# Altium schematic sheet ESP32.SchDoc
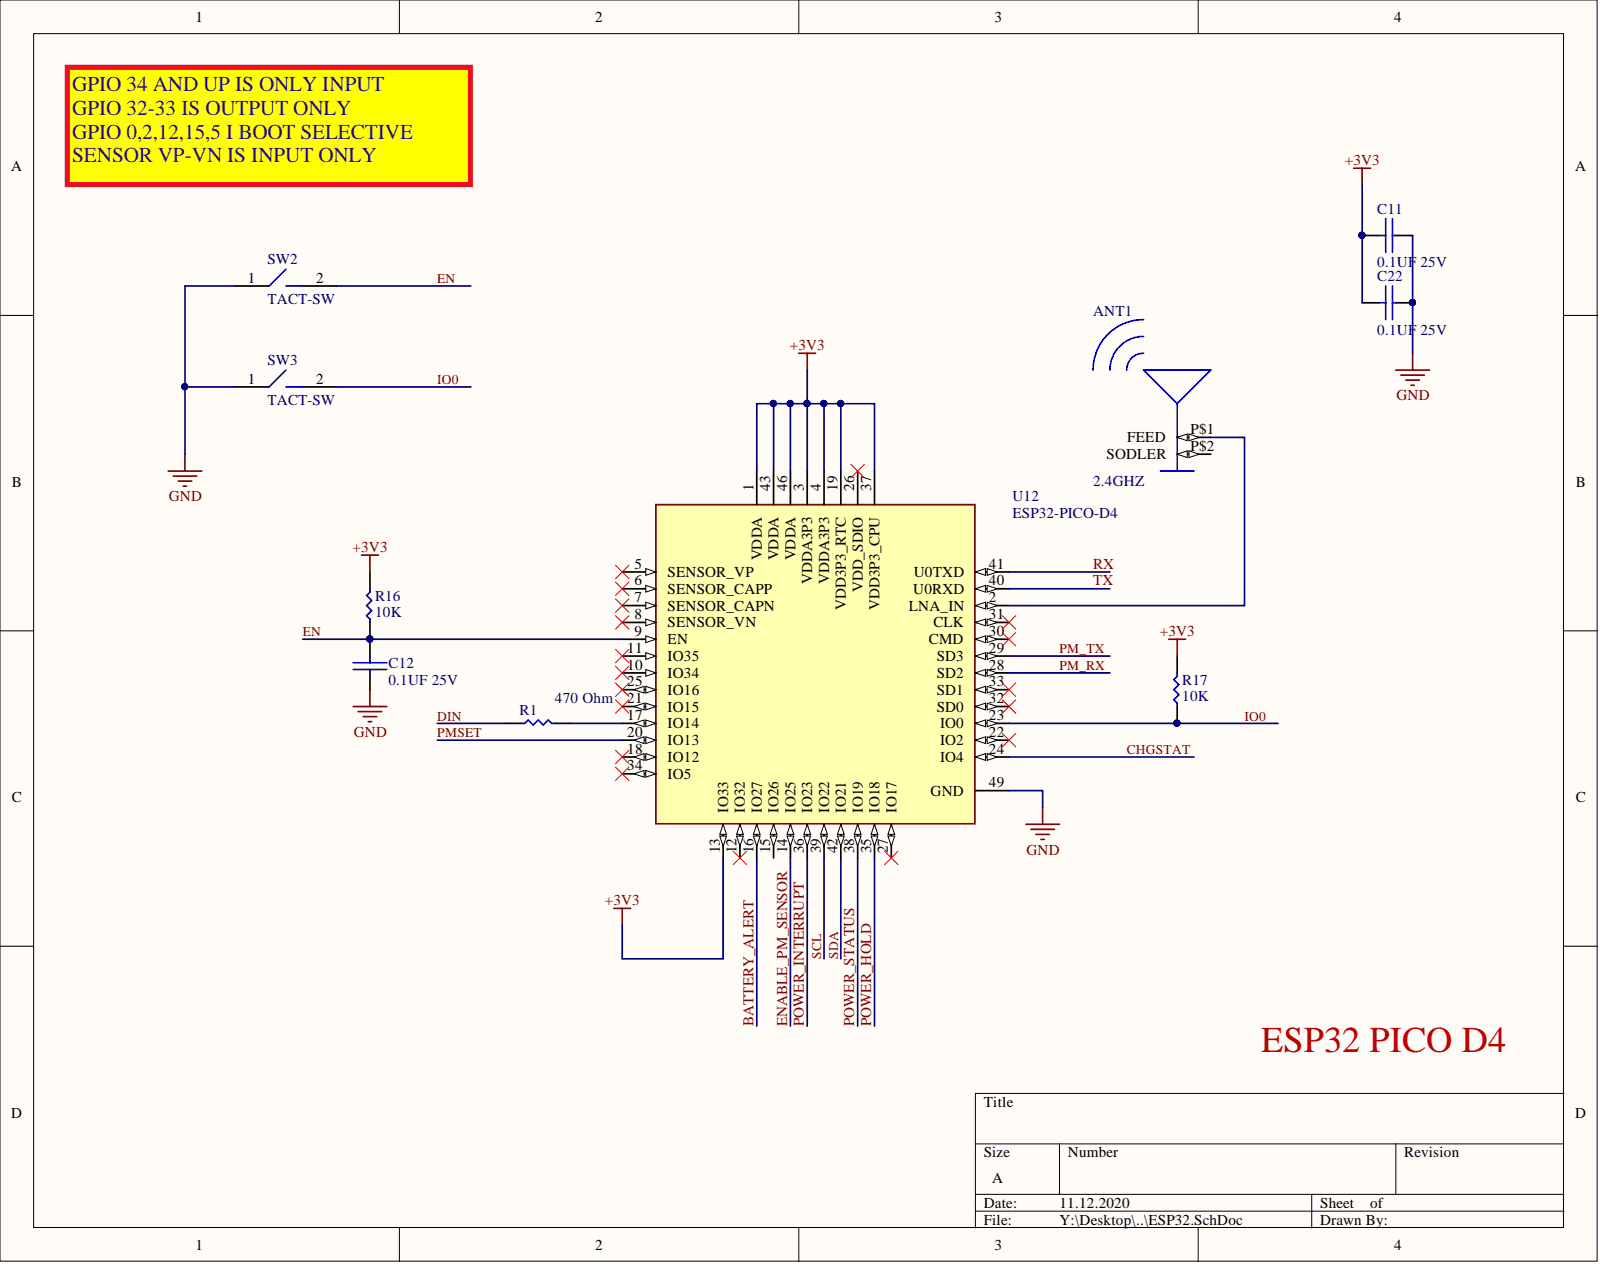
[diagram p0: ESP32.SchDoc]

## Parts
ANT1  2.4GHZ  [AN2051]
C11  0.1UF 25V  [C0603]
C12  0.1UF 25V  [C0603]
C22  0.1UF 25V  [C0603]
R1  470 Ohm  [R0603]
R16  10K  [R0603]
R17  10K  [R0603]
SW2  TACT-SW  [kmr7-switch]
SW3  TACT-SW  [kmr7-switch]
U12  ESP32-PICO-D4  [PQFN50P700X700X104-49N]

## Nets
+3V3: C11.2 C22.2 R16.2 R17.2 U12.1(VDDA) U12.3(VDDA3P3) U12.4(VDDA3P3) U12.13(IO33) U12.19(VDD3P3_RTC) U12.37(VDD3P3_CPU) U12.43(VDDA) U12.46(VDDA)
GND: C11.1 C12.1 C22.1 SW2.1 SW3.1 U12.49(GND)
BATTERY_ALERT: U12.16(IO27)
CHGSTAT: U12.24(IO4)
DIN: R1.1
EN: C12.2 R16.1 SW2.2 U12.9(EN)
ENABLE_PM_SENSOR: U12.14(IO25)
IO0: R17.1 SW3.2 U12.23(IO0)
PM_RX: U12.28(SD2)
PM_TX: U12.29(SD3)
PMSET: U12.20(IO13)
POWER_HOLD: U12.35(IO18)
POWER_INTERRUPT: U12.36(IO23)
POWER_STATUS: U12.38(IO19)
RX: U12.41(U0TXD)
SCL: U12.39(IO22)
SDA: U12.42(IO21)
TX: U12.40(U0RXD)
NetANT1_P$1: ANT1.P$1 U12.2(LNA_IN)
NetR1_2: R1.2 U12.17(IO14)

Not connected: ANT1 P$2; U12 5(SENSOR_VP) 6(SENSOR_CAPP) 7(SENSOR_CAPN) 8(SENSOR_VN) 10(IO34) 11(IO35) 12(IO32) 15(IO26) 18(IO12) 21(IO15) 22(IO2) 25(IO16) 26(VDD_SDIO) 27(IO17) 30(CMD) 31(CLK) 32(SD0) 33(SD1) 34(IO5)

## Library data of the parts
ANT1 = AN2051: SMD Built-in Antenna 2.4GHz; MF: RainSun; Availability: Unavailable; Component Kind: Standard; MP: AN2051; Package: None; Price: None; SnapEDA_Link: https://www.snapeda.com/parts/AN2051/RainSun/view-part/550460/?ref=snap; Library Name: salcox.IntLib
C11 C12 C22 = 06033D104KAT2A: CAP CER 0.1UF 25V X5R 0603; Manufacturer: Kyocera AVX; Capacitance: 100 nF; Tolerance (%): ±10 %; Case Size/Dimension: 0603; Component Kind: Standard; ComponentLink1Description: https://datasheet.ciiva.com/19475/1031061-19475906.pdf; ComponentLink1URL: https://datasheet.ciiva.com/19475/1031061-19475906.pdf; ComponentLink2Description: https://datasheet.ciiva.com/28613/759405-28613274.pdf; ComponentLink2URL: https://datasheet.ciiva.com/28613/759405-28613274.pdf; ComponentLink3Description: https://datasheet.ciiva.com/16397/cx5r-16397162.pdf; ComponentLink3URL: https://datasheet.ciiva.com/16397/cx5r-16397162.pdf; ComponentLink4Description: https://datasheet.ciiva.com/28613/1666675-28613037.pdf; ComponentLink4URL: https://datasheet.ciiva.com/28613/1666675-28613037.pdf; ComponentLink5Description: https://datasheet.ciiva.com/28613/1666675-28613275.pdf; ComponentLink5URL: https://datasheet.ciiva.com/28613/1666675-28613275.pdf; ComponentLink6Description: https://datasheet.ciiva.com/152/cx5r-152227.pdf; ComponentLink6URL: https://datasheet.ciiva.com/152/cx5r-152227.pdf; ComponentLink7Description: https://datasheet.ciiva.com/152/cx5r-152226.pdf; ComponentLink7URL: https://datasheet.ciiva.com/152/cx5r-152226.pdf; ComponentLink8Description: https://datasheet.ciiva.com/2987/cx5r-2987761.pdf; ComponentLink8URL: https://datasheet.ciiva.com/2987/cx5r-2987761.pdf; ComponentLink9Description: https://datasheet.ciiva.com/152/cx5r-152225.pdf; ComponentLink9URL: https://datasheet.ciiva.com/152/cx5r-152225.pdf; ComponentLink10Description: https://datasheet.ciiva.com/2987/cx5r-2987762.pdf; ComponentLink10URL: https://datasheet.ciiva.com/2987/cx5r-2987762.pdf; Dielectric: X5R; Manufacturer Part Number: 06033D104KAT2A; Pricing 1: 1=0.32, 10=0.221, 100=0.1328, 500=0.0996, 1000=0.0841 (USD); Rated Voltage: 25 V; Stock 1: 0; Supplier 1: Digi-Key; Supplier Part Number 1: 478-1244-6-ND; Library Name: salcox.IntLib
R1 = CRCW0603-470RFKEA: SMD Resistor, Thick film 470 Ohm,  ±  1 %, 0603, CRCW0603470RFKEA, Vishay; Brand: Vishay / Dale; Power Rating: 100 mW; Resistance: 470 Ohms; Temperature Coefficient: 100 PPM / K; Tolerance: 1 %; Unit Weight: 0.000071 oz; Voltage Rating: 75 V; Case Code - in: 0603; Case Code - mm: 1608; Component Kind: Standard; ComponentLink1Description: http://www.vishay.com/docs/20035/dcrcwe3.pdf?src-supplier=Digi-Key; ComponentLink1URL: http://www.vishay.com/docs/20035/dcrcwe3.pdf?src-supplier=Digi-Key; ComponentLink2Description: http://uk.rs-online.com/webdocs/13ec/0900766b813ecbec.pdf?src-supplier=RSComponents; ComponentLink2URL: http://uk.rs-online.com/webdocs/13ec/0900766b813ecbec.pdf?src-supplier=RSComponents; ComponentLink3Description: https://www.verical.com/server-webapp/html/getDatasheet?partID=45798&src-supplier=Verical; ComponentLink3URL: https://www.verical.com/server-webapp/html/getDatasheet?partID=45798&src-supplier=Verical; ComponentLink4Description: http://www.vishay.com/docs/20008/dcrcw.pdf?src-supplier=Avnet+Europe; ComponentLink4URL: http://www.vishay.com/docs/20008/dcrcw.pdf?src-supplier=Avnet+Europe; ComponentLink5Description: http://www.vishay.com/docs/20035/dcrcwe3.pdf?src-supplier=Avnet; ComponentLink5URL: http://www.vishay.com/docs/20035/dcrcwe3.pdf?src-supplier=Avnet; ComponentLink6Description: http://www.mouser.com/ds/2/427/dcrcwe3-109170.pdf?src-supplier=Mouser; ComponentLink6URL: http://www.mouser.com/ds/2/427/dcrcwe3-109170.pdf?src-supplier=Mouser; ComponentLink7Description: http://www.farnell.com/datasheets/2310790.pdf?src-supplier=Element14; ComponentLink7URL: http://www.farnell.com/datasheets/2310790.pdf?src-supplier=Element14; ComponentLink8Description: http://www.farnell.com/datasheets/2045673.pdf?src-supplier=Element14; ComponentLink8URL: http://www.farnell.com/datasheets/2045673.pdf?src-supplier=Element14; ComponentLink9Description: https://www.distrelec.biz/Web/Downloads/a_/en/flD-CRCW-e3_data_en.pdf?mime=application%2Fpdf&src-supplier=Distrelec; ComponentLink9URL: https://www.distrelec.biz/Web/Downloads/a_/en/flD-CRCW-e3_data_en.pdf?mime=application%2Fpdf&src-supplier=Distrelec; Factory Pack Quantity: 5000; Height: 0.45 mm; Length: 1.55 mm; Manufacturer: Vishay; Manufacturer Part Number: CRCW0603-470RFKEA; Operating Temperature Range: - 55 C to + 155 C; Package / Case: 0603 (1608 metric); Packaging: Reel; Product: Thick Film Resistors SMD; Product Category: Thick Film Resistors - SMD; RoHS: Details; Series: CRCW; Stock 1: 0; Supplier 1: Digi-Key; Supplier Part Number 1: 541-470HTR-ND; Termination Style: SMD/SMT; Width: 0.85 mm; Library Name: salcox.IntLib
R16 R17 = RC0603FR-0710KL: RES SMD 10K OHM 1% 1/10W 0603; Manufacturer: Yageo; Power (Watts): 0.1W, 1/10W; Temperature Coefficient: ±100ppm/°C; Category: Resistors; Component Kind: Standard; ComponentLink1Description: http://www.yageo.com/documents/recent/PYu-RC_Group_51_RoHS_L_8.pdf; ComponentLink1URL: http://www.yageo.com/documents/recent/PYu-RC_Group_51_RoHS_L_8.pdf; Composition: Thick Film; Failure Rate: -; Features: Moisture Resistant; Height - Seated (Max): 0.022" (0.55mm); Manufacturer Part Number: RC0603FR-0710KL; Operating Temperature: -55°C ~ 155°C; Package / Case: 0603 (1608 Metric); Packaging: Digi-Reel®; Part Status: Active; Pricing 1: 1=0.1, 10=0.015, 100=0.006, 1000=0.00268, 2500=0.00233 (USD); RoHS: RoHS Compliant; Size / Dimension: 0.063" L x 0.032" W (1.60mm x 0.80mm); Stock 1: 5916612; Supplier 1: Digi-Key; Supplier Device Package: 0603; Supplier Part Number 1: 311-10.0KHRDKR-ND; Tolerance: ±1%; Library Name: salcox.IntLib
SW2 SW3 = KMR731NGLFS: switch; Manufacturer: ITT C&K; Actuator Height off PCB, from Pins: 2.50mm; Actuator Orientation: Top Actuated; Actuator Type: Standard; Circuit: SPST-NO; Contact Rating @ Voltage: 0.05A @ 32VDC; Illumination: Non-Illuminated; Ingress Protection: IP67 - Dust Tight, Waterproof; Mechanical Life: 150,000 Cycles; Operating Force: 300gf; Outline: 4.20mm x 2.80mm; Switch Function: Off-Mom; Actuator Material: -; Category: Switches; Component Kind: Standard; Family: Tactile Switches; Features: -; Illumination Type, Color: -; Illumination Voltage (Nominal): -; Manufacturer Part Number: KMR731NGLFS; Mounting Type: Surface Mount; Operating Temperature: -40°C ~ 85°C; Packaging: Tape & Reel (TR); Pricing 1: 1=0.34, 10=0.333, 50=0.309, 100=0.298, 1000=0.203, 10000=0.167 (USD); Series: KMR7; Stock 1: 5277; Supplier 1: Mouser; Supplier Part Number 1: 611-KMR731NGLFS; Switch Travel: -; Termination Style: Gull Wing; Library Name: salcox.IntLib
U12 = ESP32-PICO-D4: Bluetooth, WiFi 802.11b/g/n, Bluetooth v4.2 + EDR, Class 1, 2 and 3 Transceiver Module 2.4GHz ~ 2.5GHz Surface Mount; MF: Espressif Systems; Availability: Unavailable; Component Kind: Standard; MP: ESP32-PICO-D4; Package: SMD-48 Espressif Systems; Price: None; SnapEDA_Link: https://www.snapeda.com/parts/ESP32-PICO-D4/Espressif%20Systems/view-part/2762121/?ref=snap; Library Name: salcox.IntLib
